# Altium schematic sheet Q1_STM32_Buck_MCU.SchDoc(Q1_STM32_Buck_MCU)
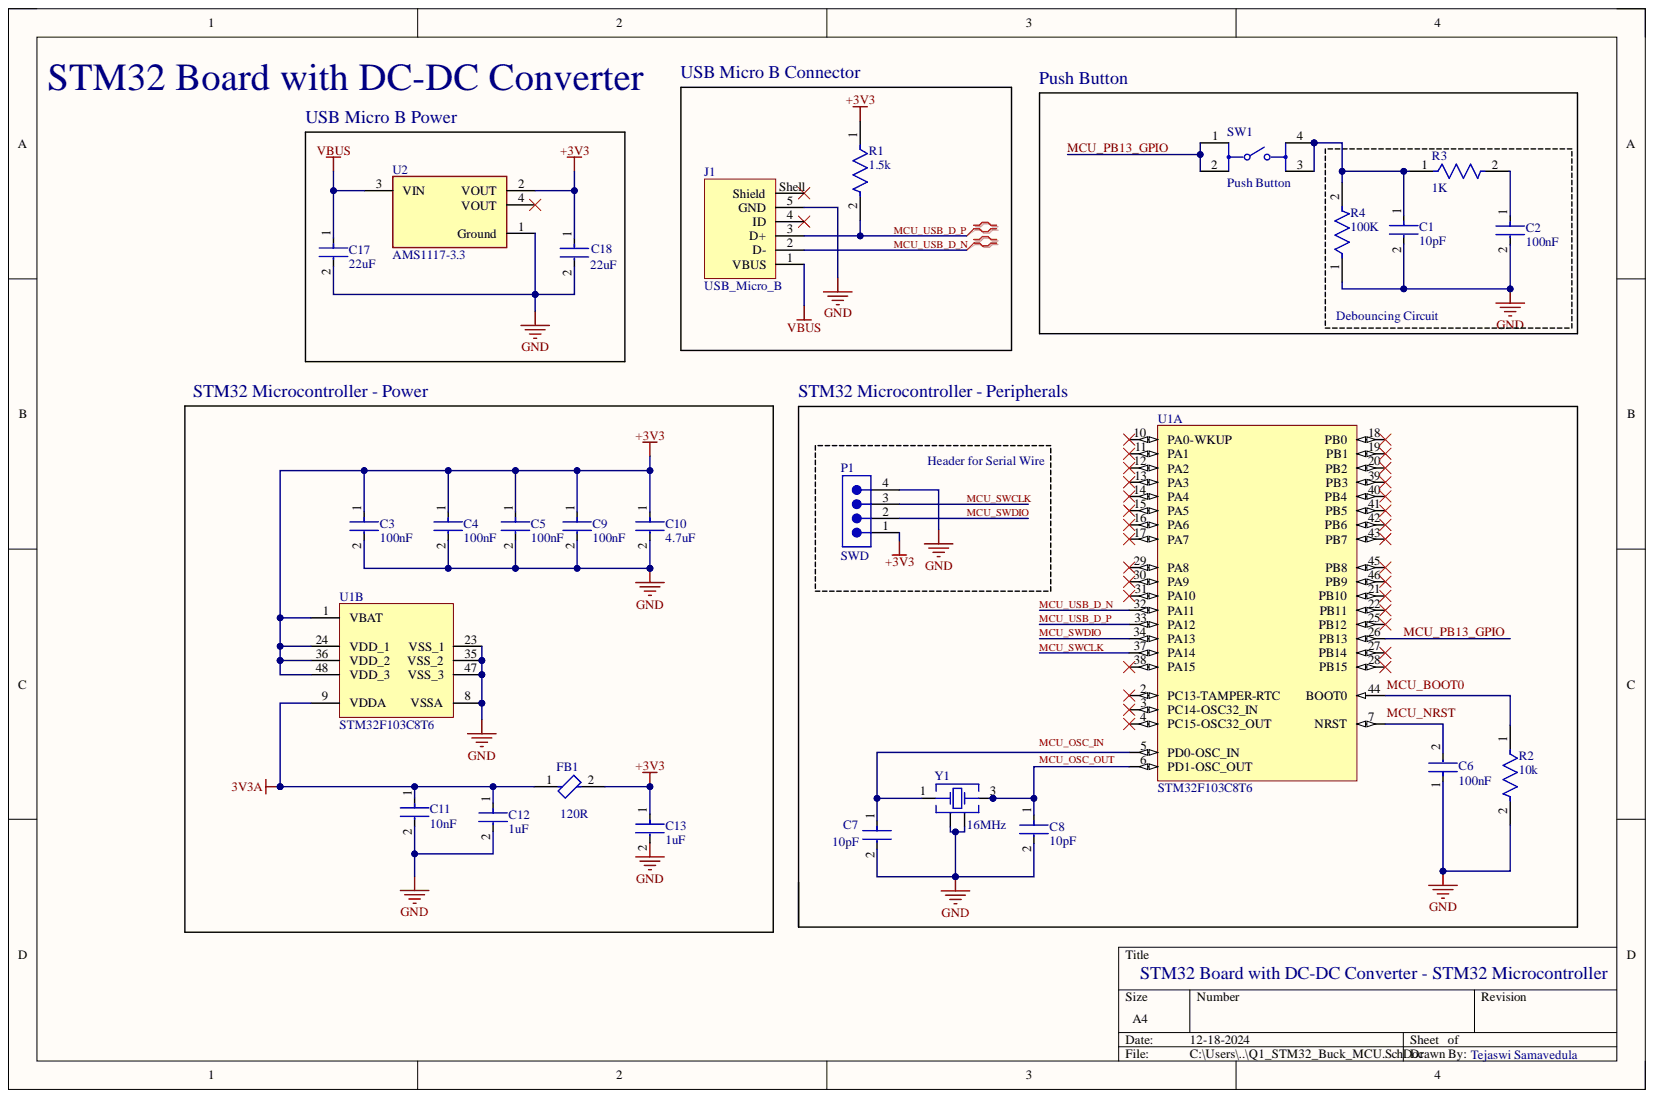
[diagram p0: Q1_STM32_Buck_MCU.SchDoc]

## Parts
C1  10pF  [FP-CC0402-MFG]
C2  100nF  [FP-CC0402-MFG]
C3  100nF  [FP-CC0402-MFG]
C4  100nF  [FP-CC0402-MFG]
C5  100nF  [FP-CC0402-MFG]
C6  100nF  [FP-CC0402-MFG]
C7  10pF  [FP-CC0402-MFG]
C8  10pF  [FP-CC0402-MFG]
C9  100nF  [FP-CC0402-MFG]
C10  4.7uF  [FP-GRM188-0_2-IPC_A]
C11  10nF  [FP-CC0402-MFG]
C12  1uF  [FP-CC0402-MFG]
C13  1uF  [FP-CC0402-MFG]
C17  22uF  [FP-GRM188-0_2-IPC_C]
C18  22uF  [FP-GRM188-0_2-IPC_C]
FB1  120R  [FP-BLM15-0_05-IPC_B]
J1  USB_Micro_B  [629105150521]
P1  SWD  [61300411121]
R1  1.5k  [FP-ERA2A-MFG]
R2  10k  [FP-ERA2A-MFG]
R3  1K  [FP-RCA0603-e3-MFG]
R4  100K  [FP-RK73H1J-IPC_C]
SW1  Push Button  [FP-1977223-1-MFG]
U1  STM32F103C8T6  [STM-LQFP48_L]  (parts A,B)
U2  AMS1117-3.3  [FP-AMS1117-IPC_C]
Y1  16MHz  [WE-XTAL_IQXC-42]

## Nets
3V3A: C3.1 C4.1 C5.1 C9.1 C10.1 C11.1 C12.1 C13.1 C18.1 FB1.1 FB1.2 P1.1 R1.1 U1.1(VBAT) U1.9(VDDA) U1.24(VDD_1) U1.36(VDD_2) U1.48(VDD_3) U2.2(VOUT)
GND: C1.2 C2.2 C3.2 C4.2 C5.2 C6.1 C7.2 C8.2 C9.2 C10.2 C11.2 C12.2 C13.2 C17.2 C18.2 J1.5(GND) P1.4 R2.2 R4.1 U1.8(VSSA) U1.23(VSS_1) U1.35(VSS_2) U1.47(VSS_3) U2.1(Ground) Y1.2 Y1.4
VBUS: C17.1 J1.1(VBUS) U2.3(VIN)
MCU_PB13_GPIO: SW1.1 SW1.2 U1.26(PB13)
MCU_SWCLK: P1.3 U1.37(PA14)
MCU_SWDIO: P1.2 U1.34(PA13)
MCU_USB_D_N: J1.2(D-) U1.32(PA11)
MCU_USB_D_P: J1.3(D+) R1.2 U1.33(PA12)
NetC1_1: C1.1 R3.1 R4.2 SW1.3 SW1.4
NetC2_1: C2.1 R3.2
NetC6_2: C6.2 U1.7(NRST)
NetC7_1: C7.1 U1.5(PD0-OSC_IN) Y1.1
NetC8_1: C8.1 U1.6(PD1-OSC_OUT) Y1.3
NetR2_1: R2.1 U1.44(BOOT0)
MCU_BOOT0:
MCU_NRST:
MCU_OSC_IN:
MCU_OSC_OUT:

Not connected: J1 4(ID) Shell(Shield); U1 2(PC13-TAMPER-RTC) 3(PC14-OSC32_IN) 4(PC15-OSC32_OUT) 10(PA0-WKUP) 11(PA1) 12(PA2) 13(PA3) 14(PA4) 15(PA5) 16(PA6) 17(PA7) 18(PB0) 19(PB1) 20(PB2) 21(PB10) 22(PB11) 25(PB12) 27(PB14) 28(PB15) 29(PA8) 30(PA9) 31(PA10) 38(PA15) 39(PB3) 40(PB4) 41(PB5) 42(PB6) 43(PB7) 45(PB8) 46(PB9); U2 4(VOUT)

## Library data of the parts
C1-C9 C11-C13 = CMP-03422-001312-1: CAP CER 0.1UF 16V X5R 0402; Manufacturer: YAGEO; Manufacturer Part Number: CC0402MRX5R7BB104; Automotive Grade: NA; Material: Ceramic; Temperature Coefficient: 30ppm/°C; Tolerance: 0.2; Automotive: No; Category: Cap; Component Kind: Standard; ComponentLink2Description: Datasheet; ComponentLink2URL: http://www.yageo.com/documents/recent/UPY-GP_NP0_16V-to-50V_16.pdf; Device Class L1: Passive Components; Device Class L2: Capacitors; Device Class L3: Ceramic Capacitors; Digikey Description: CAP CER 0.1UF 16V X5R 0402; Footprint Url: http://www.yageo.com/exep/pages/download/literatures/UPY-C_GEN_24.pdf; Height: 0.55mm; Ipc Land Pattern Name: CAPC10050X50; Lead Free: Yes; Library Name: Altium Content Vault; Mouser Description: Multilayer Ceramic Capacitors MLCC - SMD/SMT 100nF 16V X5R 20%; Octopart: https://octopart.com/cc0402mrx5r7bb104-yageo-33242280; Package: CC0402; RoHS: TRUE; Temperature: -55°C to +125°C; Temperature Characteristic: X5R; Temperature Range High: +125°C; Temperature Range Low: -55°C; Voltage Rating: 16 V
  C1 C7 C8: Capacitance: 10 pF; Value: 10 pF
  C2-C6 C9: Capacitance: 100 nF; Value: 100 nF
  C11: Capacitance: 10 nF; Value: 10 nF
  C12 C13: Capacitance: 1uF; Value: 1uF
C10 = CMP-06035-002362-1: Chip Multilayer Ceramic Capacitors for General Purpose, 0603, 4.7uF, X7S, 22%, 10%, 16V; Capacitance: 4.7uF; Component Kind: Standard; Dielectric: X7S; Length: 1.6mm; Library Name: Altium Content Vault; Thickness: 800um; Tolerance: 10%; Voltage Rating (DC): 16V; Width: 800um
C17 C18 = CMP-2000-06337-3: Chip Multilayer Ceramic Capacitors for General Purpose, 0603, 22uF, X5R, 15%, 20%, 10V; Capacitance: 22uF; Case Code (Imperial): 0603; Case Code (Metric): 1608; Case/Package: 0603; Component Kind: Standard; Depth: 0.8mm; Dielectric: X5R; Height: 0.8mm; Lead Free: Lead Free; Length: 1.6mm; Library Name: Altium Content Vault; Material: Ceramic; Max Operating Temperature: 85°C; Min Operating Temperature: -55°C; Mount: Surface Mount; Package Quantity: 4000; Packaging: Tape and Reel; REACH SVHC: No SVHC; Termination: SMD/SMT; Thickness: 0.039inch; Tolerance: 20%; Voltage Rating: 10V; Voltage Rating (DC): 10V; Weight: 0.000222oz; Width: 0.8mm
FB1 = CMP-0686-00181-5: Chip Ferrite Bead, 0402, 1000Ω @ 100MHz, 0.65Ω, 25%, 300mA; DC Current: 200mA; DC Resistance (DCR): 650mR; DC Resistance-Max: 1R; Impedance: 120; Number of Circuits: 1; Shielding: Unshielded; Case Code (Imperial): 0402; Case Code (Metric): 1005; Case/Package: 0402; Component Kind: Standard; Core Material: Ferrite; Current Rating: 300mA; Depth: 0.55mm; Frequency: 100MHz; Height: 0.5mm; Lead Free: Lead Free; Length: 1mm; Library Name: Altium Content Vault; Material: Ferrite; Max Current Rating: 300mA; Max DC Current: 200mA; Max Operating Temperature: 125°C; Military Standard: Not; Min Operating Temperature: -55°C; Number of Lines: 1; Number of Pins: 2; Package Quantity: 1; Packaging: Tape and Reel; Radiation Hardening: No; REACH SVHC: No SVHC; RoHS Compliant: Yes; Series: BLM15AG_SN; Termination: SMD/SMT; Test Frequency: 100MHz; Tolerance: 25%; Width: 0.5mm
J1 = CMP-1502-03252-3: NexarPartId: 52645113; Connector Type: USB; Contact Resistance: 30mΩ; Current Rating: 3A; Gender: Receptacle; Insulation Resistance: 1GΩ; Number of Contacts: 5; Operating Supply Voltage: 30V; USB Standard: USB 2.0; Component Kind: Standard; Contact Material: Gold; Contact Plating: Gold; Features: Shielded; Height: 2.86mm; Lead Free: Lead Free; Library Name: Altium Content Vault; Max Operating Temperature: 85°C; Min Operating Temperature: -40°C; Mount: Horizontal, Surface Mount; Number of Pins: 5; Orientation: Horizontal; Packaging: Tape and Reel; REACH SVHC: Unknown; RoHS: Compliant; Termination: SMD/SMT; Voltage Rating: 30V
P1 = CMP-1502-01064-3: NexarPartId: 32855522; Connector Type: Male Pin; Contact Resistance: 20mΩ; Current Rating: 3A; Gender: Male; Number of Contacts: 4; Operating Supply Voltage: 250V; Pitch: 2.54mm; Color: Black; Component Kind: Standard; Contact Material: Copper; Contact Mating Length: 5.9944mm; Contact Plating: Gold; Height: 8.89mm; Lead Free: Lead Free; Lead Pitch: 2.54mm; Library Name: Altium Content Vault; Max Operating Temperature: 105°C; Min Operating Temperature: -40°C; Mount: Through Hole; Number of Pins: 4; Number of Positions: 4; Number of Rows: 1; Orientation: Vertical; Packaging: Bulk; REACH SVHC: Unknown; RoHS: Compliant; Termination: Solder; Voltage Rating: 250V
R1 = CMP-2002-05875-2: RES SMD 10K OHM 0.1% 1/16W 0402; Manufacturer: Panasonic; Manufacturer Part Number: ERA-2AEB103X; Automotive Grade: Grade 0; Power Rating: 0.063W; Resistance: 1.5kΩ; Temperature Coefficient: 25ppm/°C; Value: 1.5kΩ; Voltage Rating: 50V; Automotive: Yes; Category: Res; Component Kind: Standard; ComponentLink2Description: Datasheet; ComponentLink2URL: https://industrial.panasonic.com/cdbs/www-data/pdf/RDM0000/AOA0000C307.pdf; Composition: Metal Film; Device Class L1: Passive Components; Device Class L2: Resistors; Device Class L3: Chip SMD Resistors; Digikey Description: RES SMD 10K OHM 0.1% 1/16W 0402; Footprint Url: https://industrial.panasonic.com/cdbs/www-data/pdf/RDM0000/DMM0000COL17.pdf; Height: 0.4mm; Lead Free: Yes; Library Name: Altium Content Vault; Mouser Description: Thin Film Resistors - SMD 0402 1/16W 10Kohms; Octopart: https://octopart.com/era-2aeb103x-panasonic-9251377; Package: ERA2A; RoHS: TRUE; Series: ERA; Temperature: -55°C to +155°C; Temperature Range High: +155°C; Temperature Range Low: -55°C; Tolerance: 0.1%
R2 = CMP-2002-05875-2: RES SMD 10K OHM 0.1% 1/16W 0402; Manufacturer: Panasonic; Manufacturer Part Number: ERA-2AEB103X; Automotive Grade: Grade 0; Power Rating: 0.063W; Resistance: 10kΩ; Temperature Coefficient: 25ppm/°C; Value: 10kΩ; Voltage Rating: 50V; Automotive: Yes; Category: Res; Component Kind: Standard; ComponentLink2Description: Datasheet; ComponentLink2URL: https://industrial.panasonic.com/cdbs/www-data/pdf/RDM0000/AOA0000C307.pdf; Composition: Metal Film; Device Class L1: Passive Components; Device Class L2: Resistors; Device Class L3: Chip SMD Resistors; Digikey Description: RES SMD 10K OHM 0.1% 1/16W 0402; Footprint Url: https://industrial.panasonic.com/cdbs/www-data/pdf/RDM0000/DMM0000COL17.pdf; Height: 0.4mm; Lead Free: Yes; Library Name: Altium Content Vault; Mouser Description: Thin Film Resistors - SMD 0402 1/16W 10Kohms; Octopart: https://octopart.com/era-2aeb103x-panasonic-9251377; Package: ERA2A; RoHS: TRUE; Series: ERA; Temperature: -55°C to +155°C; Temperature Range High: +155°C; Temperature Range Low: -55°C; Tolerance: 0.1%
R3 = CMP-00030-22249381-1: RCA06031K00FKEA SMD Chip Resistor, 1 kOhm, ± 1%, 100 mW, 0603 [1608 Metric], Thick Film, Anti-Sulfur; Max Power Dissipation: 100mW; Resistance: 1kΩ; Temperature Coefficient: 100ppm/°C; Voltage Rating: 75V; Case Code (Imperial): 0603; Case Code (Metric): 1608; Case/Package: 0603; Component Kind: Standard; Composition: Thick Film; Contact Plating: Tin; Depth: 850µm; Features: Anti-Sulfur, Automotive AEC-Q200; Height: 508µm; Length: 1.55mm; Library Name: Altium Content Vault; Max Operating Temperature: 155°C; Military Standard: Not; Min Operating Temperature: -55°C; Mount: Surface Mount; NexarPartId: 22249381; Number of Terminations: 2; Packaging: Cut Tape; Power Rating: 100mW; Radiation Hardening: No; REACH SVHC: No SVHC; RoHS: Compliant; Schedule B: 8533210045; Tolerance: 1%; Voltage Rating (DC): 75V; Width: 838.2µm
R4 = CMP-04015-074272-1: 100kΩ ±1% 0.1W Chip Resistor 0603 Thick Film AEC-Q200 Qualified; Power Rating: 100mW; Resistance: 100kR; Temperature Coefficient: 100ppm/°C; Voltage Rating: 50V; Case Code (Imperial): 0603; Case Code (Metric): 1608; Case/Package: 0603; Component Kind: Standard; Height: 0.45mm; Lead Free: Contains Lead; Length: 1.6mm; Library Name: Altium Content Vault; Max Operating Temperature: 155°C; Min Operating Temperature: -55°C; Package Quantity: 5000; Packaging: Tape and Reel; Series: RK73H; Termination: SMD/SMT; Tolerance: 1%; Width: 0.8mm
SW1 = CMP-03790-42203485-1: 1977223-1 Pushbutton Switch, SPST, Momentary, 0.05A, 24VDC, Solder Terminal, Panel Mount; Manufacturer Lifecycle Status: ACTIVE; Actuator Length: 700µm; Actuator Material: Nylon, Polyamide; Contact Current Rating: 50mA; Illumination Type: Illuminated, Non-Illuminated; Max Voltage Rating (DC): 24V; Mechanical Life: 1Mcycles; Number of Positions: 2; Switch Function: Off-Mom; Switch Travel: 250µm; Case/Package: SMD/SMT; Component Kind: Standard; Contact Plating: Silver; Current Rating: 50mA; ELV: Compliant; Height: 4.3mm; Housing Material: Polyphenylene; Library Name: Altium Content Vault; Lifecycle Status: Production; Material: Polyamide; Max Operating Temperature: 105°C; Min Operating Temperature: -40°C; Mount: Surface Mount; NexarPartId: 42203485; Packaging: Bulk; Radiation Hardening: No; REACH SVHC: No SVHC; RoHS: Compliant; Termination: Gull Wing; Throw Configuration: SPST; Type: Switch; Voltage Rating (DC): 24V
U1 = CMP-0237-00215-3: ARM Cortex-M3 32-bit MCU, 64 KB Flash, 20 KB Internal RAM, 37 I/Os, 48-pin LQFP, -40 to 85 degC, Tray; A/D Converter: 2x12-bit (10 Channel); FLASH Size (Prog) (kB): 64; I/Os (High Current): 37; Internal RAM Size (kB): 20; Operating Frequency(F) (Processor speed) (MHz): 72; Supply Voltage(Vcc) min (V): 2; Component Kind: Standard; ComponentLink1Description: Manufacturer URL; ComponentLink1URL: http://www.st.com/web/en/home.html; ComponentLink2Description: Datasheet; ComponentLink2URL: http://www.st.com/st-web-ui/static/active/en/resource/technical/document/datasheet/CD00161566.pdf; ComponentLink3Description: Package Specification; ComponentLink3URL: http://www.st.com/st-web-ui/static/active/en/resource/technical/document/datasheet/DM00058181.pdf; Core: ARM Cortex-M3; DatasheetVersion: Rev. 16; Library Name: Altium Content Vault; Mounting Technology: Surface Mount; PackageDescription: 48-Pin Low Profile Quad Flat Package, 7 x 7 mm Body, 0.5 mm Pitch; PackageReference: LQFP48; PackageVersion: Rev. 6, 06/2013; Packing: Tray; PartNumber: STM32F103C8T6
U2 = CMP-209535-000001-1: LDO Voltage Regulators 1A, 3.3V; Manufacturer: Advanced Monolithic Systems; Dropout Voltage: 1.1V; Max Junction Temp: +125°C; Max Power Dissipation: 1.2W; Max Supply Voltage: 15V; Nominal Supply Current: 5mA; Number Of Outputs: 1; Output Type: Fixed; Standoff Height: 0.64mm; Automotive: No; Category: IC; Component Kind: Standard; ComponentLink2Description: Datasheet; ComponentLink2URL: http://www.advanced-monolithic.com/pdf/ds1117.pdf; Device Class L1: Integrated Circuits (ICs); Device Class L2: Power Management ICs; Device Class L3: Voltage Regulators - Linear; Height: 1.8mm; Ipc Land Pattern Name: SOT229P700X180-4; Lead Free: Yes; Library Name: Altium Content Vault; Manufacturer Part Number: AMS1117-3.3; Octopart: https://octopart.com/ams1117-3.3-advanced+monolithic+systems-25899300; Output Current: 1A; Output Voltage: 3.3V; Package: AMS1117; RoHS: TRUE; Temperature: -40°C to +125°C; Temperature Range High: +125°C; Temperature Range Low: -40°C
Y1 = CMP-03913-116855783-1: 830108288709 WE-XTAL Quartz Crystal; Component Kind: Standard; Frequency: 16MHz; Height: 500µm; Length: 2mm; Library Name: Altium Content Vault; Max Operating Temperature: 105°C; Min Operating Temperature: -40°C; NexarPartId: 116855783; Width: 1.6mm
